# Altium schematic sheet Power_v2.SchDoc(Power_v2)
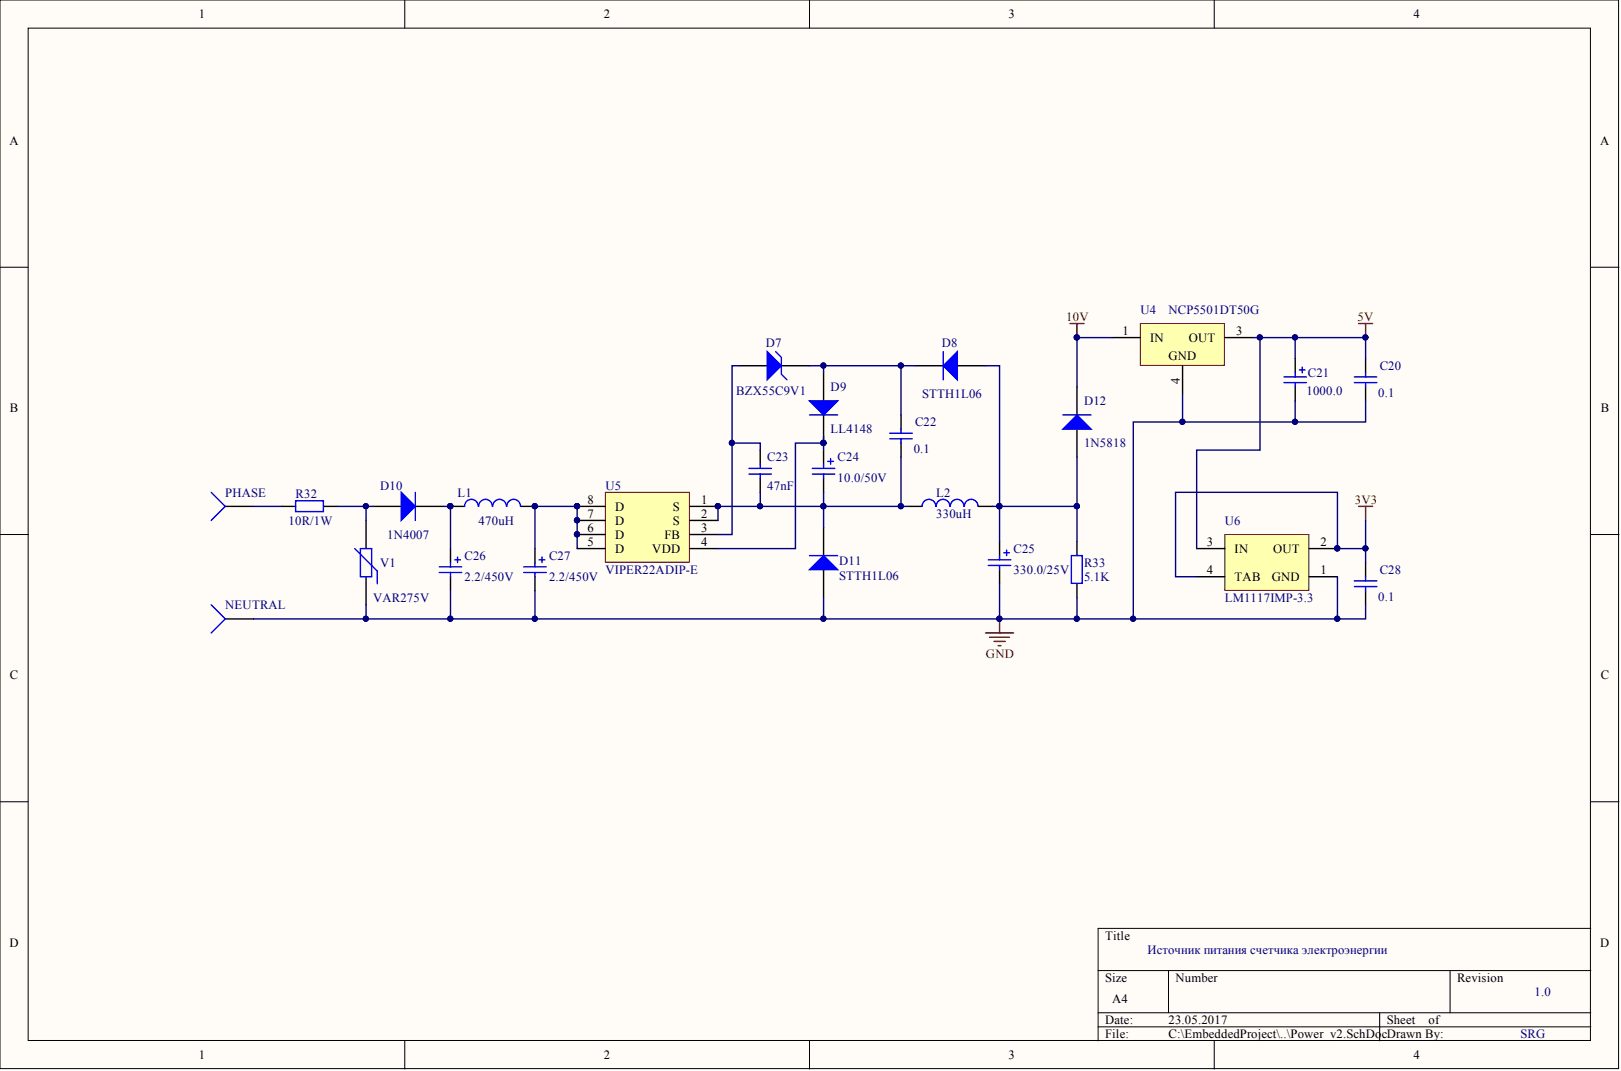
[diagram p0: Power_v2.SchDoc]

## Parts
C20  value 0.1  [C1206]  (hidden comment: Cap)
C21  value 1000.0  [El-d10-h16-F5.08]  (hidden comment: Cap Pol2)
C22  value 0.1  [C1206]  (hidden comment: Cap)
C23  value 47nF  [C1206]  (hidden comment: Cap)
C24  value 10.0/50V  [El-d5-h11-F2.54]  (hidden comment: Cap Pol2)
C25  value 330.0/25V  [El-d8-h12-F3.81]  (hidden comment: Cap Pol2)
C26  value 2.2/450V  [El-d10-h13-F5.08]  (hidden comment: Cap Pol2)
C27  value 2.2/450V  [El-d10-h13-F5.08]  (hidden comment: Cap Pol2)
C28  value 0.1  [C1206]  (hidden comment: Cap)
D7  value BZX55C9V1  [DO-35-300]  (hidden comment: D Zener)
D8  value STTH1L06  PartNumber: STTH1L06  [DO-214AA]  (hidden comment: Diode)
D9  value LL4148  PartNumber: LL4148  [D1206]  (hidden comment: Diode)
D10  value 1N4007  PartNumber: 1N4007  [DO-41-300]  (hidden comment: Diode)
D11  value STTH1L06  PartNumber: STTH1L06  [DO-214AA]  (hidden comment: Diode)
D12  value 1N5818  PartNumber: 1N5818  [DO-41-300]  (hidden comment: Diode)
L1  value 470uH  [Dr-CW8A]  (hidden comment: Inductor)
L2  value 330uH  [Dr-CW8A]  (hidden comment: Inductor)
NEUTRAL  Socket  [PIN1-PWR]  (value not drawn)
PHASE  Socket  [PIN1-PWR]  (value not drawn)
R32  value 10R/1W  [R1W CF-500]  (hidden comment: Res2)
R33  value 5.1K  [R1206]  (hidden comment: Res2)
U4  NCP5501DT50G  [TO-252_L]
U5  value VIPER22ADIP-E  PartNumber: VIPER22ADIP-E  [DIL8-300a]  (hidden comment: VIPER12ADIP)
U6  LM1117IMP-3.3  [MP04A_N]
V1  value VAR275V  [Var-10K-300]  (hidden comment: Res Varistor)

## Nets
3V3: C28.2 U6.2(OUT) U6.4(TAB)
5V: C20.2 C21.1 U4.3 U6.3(IN)
10V: D12.2 U4.1
GND: C20.1 C21.2 C25.2 C26.2 C27.2 C28.1 D11.1 NEUTRAL.1 R33.1 U4.4 U6.1(GND) V1.1
NetC22_1: C22.1 C23.1 C24.2 D11.2 L2.1 U5.1(S) U5.2(S)
NetC22_2: C22.2 D7.2 D8.2 D9.1
NetC23_2: C23.2 D7.1 U5.3(FB)
NetC24_1: C24.1 D9.2 U5.4(VDD)
NetC25_1: C25.1 D8.1 D12.1 L2.2 R33.2
NetC26_1: C26.1 D10.2 L1.1
NetC27_1: C27.1 L1.2 U5.5(D) U5.6(D) U5.7(D) U5.8(D)
NetD10_1: D10.1 R32.2 V1.2
NetPHASE_1: PHASE.1 R32.1

## Library data of the parts
C20 C22 C28 = Cap: Capacitor; PartNumber: GRM31CR72A104K; DeliveryTime: 7; Component Kind: Standard; Library Name: Miscellaneous Devices.IntLib; Price: 10.00; Signal Integrity: Cap; Simulation: CAP
C21 = Cap Pol2: Polarized Capacitor (Axial); PartNumber: TK 10X16 TKR102M1CG16; DeliveryTime: 7; Component Kind: Standard; Library Name: Miscellaneous Devices.IntLib; Price: 14.00; Signal Integrity: Cap Pol; Simulation: CAP
C23 = Cap: Capacitor; PartNumber: GRM31MR72A473K; DeliveryTime: 7; Component Kind: Standard; Library Name: Miscellaneous Devices.IntLib; Price: 4.00; Signal Integrity: Cap; Simulation: CAP
C24 = Cap Pol2: Polarized Capacitor (Axial); PartNumber: TK 5X11 TKR100M1HD11; DeliveryTime: 7; Component Kind: Standard; Library Name: Miscellaneous Devices.IntLib; Price: 3.00; Signal Integrity: Cap Pol; Simulation: CAP
C25 = Cap Pol2: Polarized Capacitor (Axial); PartNumber: TK 10X12.5; DeliveryTime: 7; Component Kind: Standard; Library Name: Miscellaneous Devices.IntLib; Price: 8.00; Signal Integrity: Cap Pol; Simulation: CAP
C26 C27 = Cap Pol2: Polarized Capacitor (Axial); PartNumber: TK 10X13, TKR2R2M2WG13; DeliveryTime: 7; Component Kind: Standard; Library Name: Miscellaneous Devices.IntLib; Price: 11.00; Signal Integrity: Cap Pol; Simulation: CAP
D7 = D Zener: Zener Diode; PartNumber: BZX55C9V1; DeliveryTime: 7; Value: BZX55C9V1; Component Kind: Standard; Library Name: Miscellaneous Devices.IntLib; Price: 2.00; Signal Integrity: D Zener; Simulation: ZENER
D8 D11 = Diode: Default Diode; DeliveryTime: 21; Component Kind: Standard; Library Name: Miscellaneous Devices.IntLib; Price: 8.00; Signal Integrity: Diode; Simulation: DIODE
D9 D10 D12 = Diode: Default Diode; DeliveryTime: 7; Component Kind: Standard; Library Name: Miscellaneous Devices.IntLib; Signal Integrity: Diode; Simulation: DIODE
  D9: Price: 1.0
  D10 D12: Price: 3.00
L1 = Inductor: PartNumber: RLB0914-471KL; DeliveryTime: 7; Component Kind: Standard; Description: Inductor; Library Name: Miscellaneous Devices.IntLib; Price: 30.00; Signal Integrity: Inductor; Simulation: INDUCTOR
L2 = Inductor: PartNumber: RLB0914-331KL; DeliveryTime: 21; Component Kind: Standard; Description: Inductor; Library Name: Miscellaneous Devices.IntLib; Price: 30.00; Signal Integrity: Inductor; Simulation: INDUCTOR
R32 = Res2: Resistor; PartNumber: ROX1SJ10R; DeliveryTime: 21; Component Kind: Standard; Library Name: Miscellaneous Devices.IntLib; Price: 11.00; Signal Integrity: Res; Simulation: RESISTOR
R33 = Res2: Resistor; PartNumber: RC1206JK-075K1L; DeliveryTime: 7; Component Kind: Standard; Library Name: Miscellaneous Devices.IntLib; Price: 0.90; Signal Integrity: Res; Simulation: RESISTOR
U4 = LM78M05CDT: 3-Terminal Positive Voltage Regulators, 3-pin TO-252; DeliveryTime: 21; Component Kind: Standard; Library Name: National Semiconductor LDO.IntLib; PartNumber: NCP5501DT50G; Price: 52.00; Value: NCP5501DT50G
U5 = VIPER12ADIP: Low power offline switched-mode power; DeliveryTime: 5; Component Kind: Standard; Library Name: Schlib1.SchLib; Price: 28.00
U6 = LM1117IMP-3.3: 800mA Low-Dropout Linear Regulator, 4-pin SOT-223; PartNumber: LM1117IMP-3.3/NOPB; DeliveryTime: 7; Component Kind: Standard; Library Name: National Semiconductor LDO.IntLib; Price: 43.00; Value: LM1117IMP-3.3
V1 = Res Varistor: Varistor (Voltage-Sensitive Resistor); PartNumber: B72214-S 271-K101 (S14K275), 275 В, 71 Дж; DeliveryTime: 7; Component Kind: Standard; Library Name: Miscellaneous Devices.IntLib; Price: 25.00
NEUTRAL PHASE = Socket: Component Kind: Standard; Description: Socket; LatestRevisionDate: 17-Jul-2002; LatestRevisionNote: Re-released for DXP Platform.; Library Name: Miscellaneous Connectors.IntLib; Signal Integrity: Connector; Publisher: Altium Limited
